# Altium schematic sheet power.SchDoc(power)
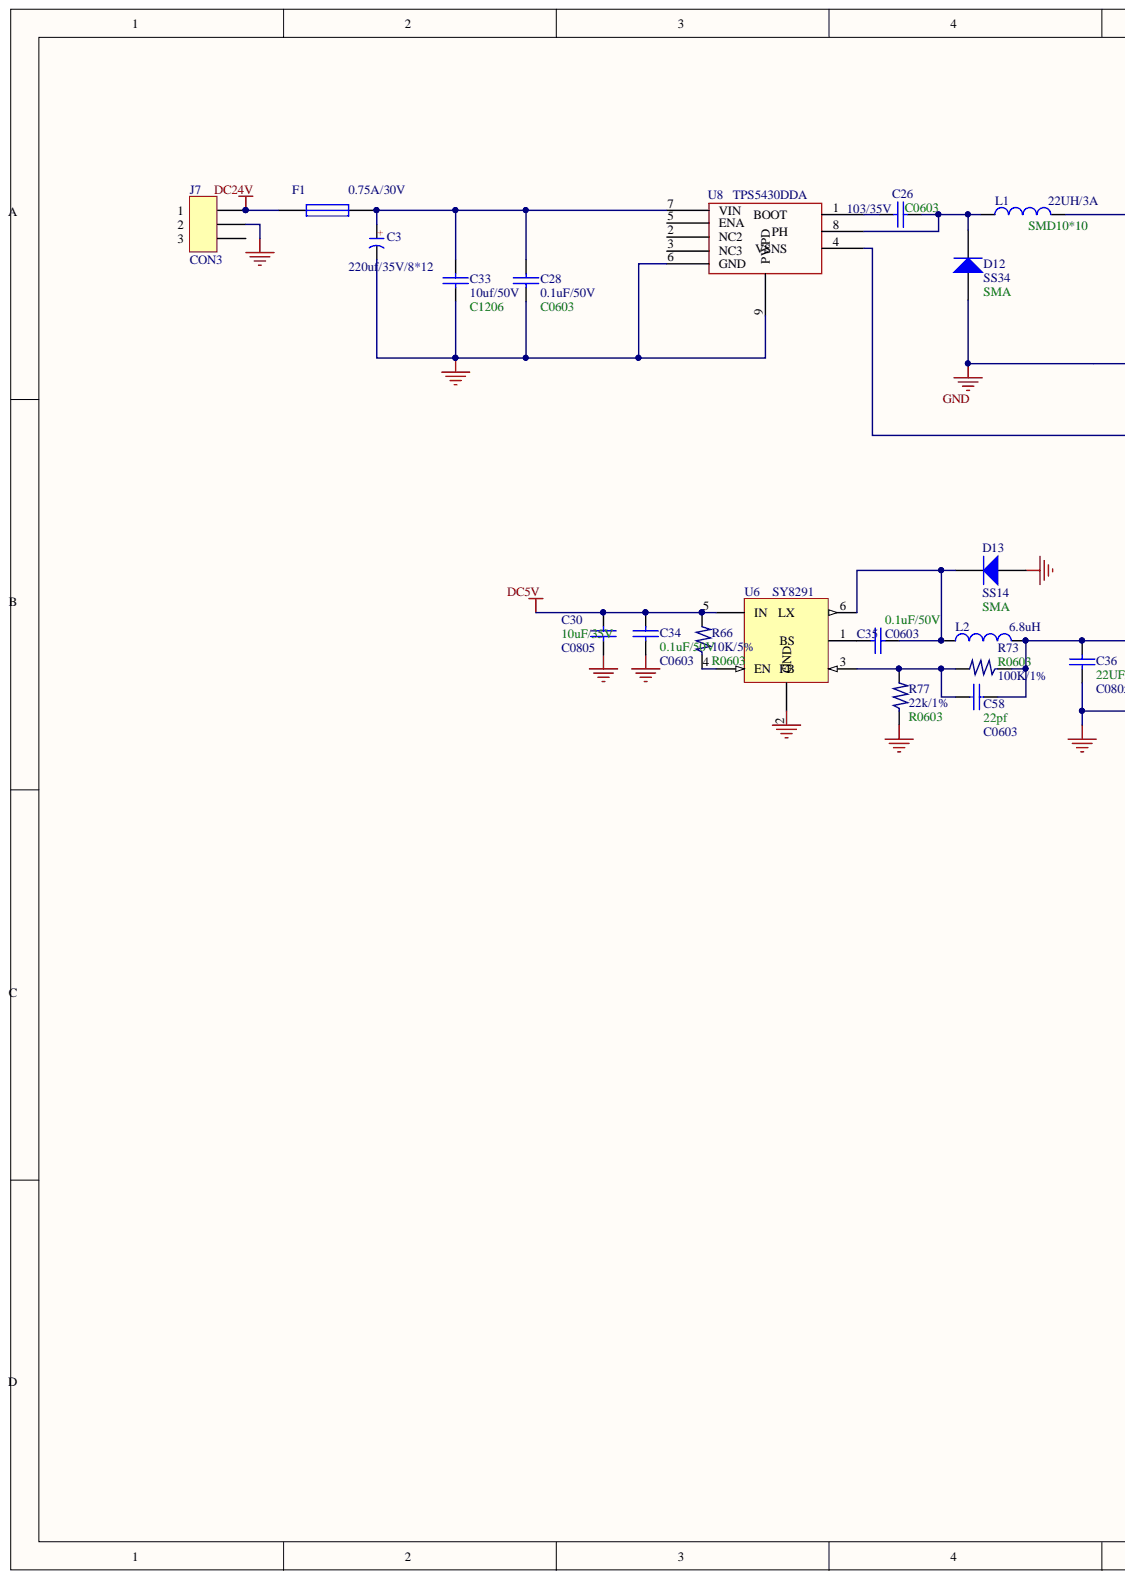
[diagram p0: power.SchDoc, left]
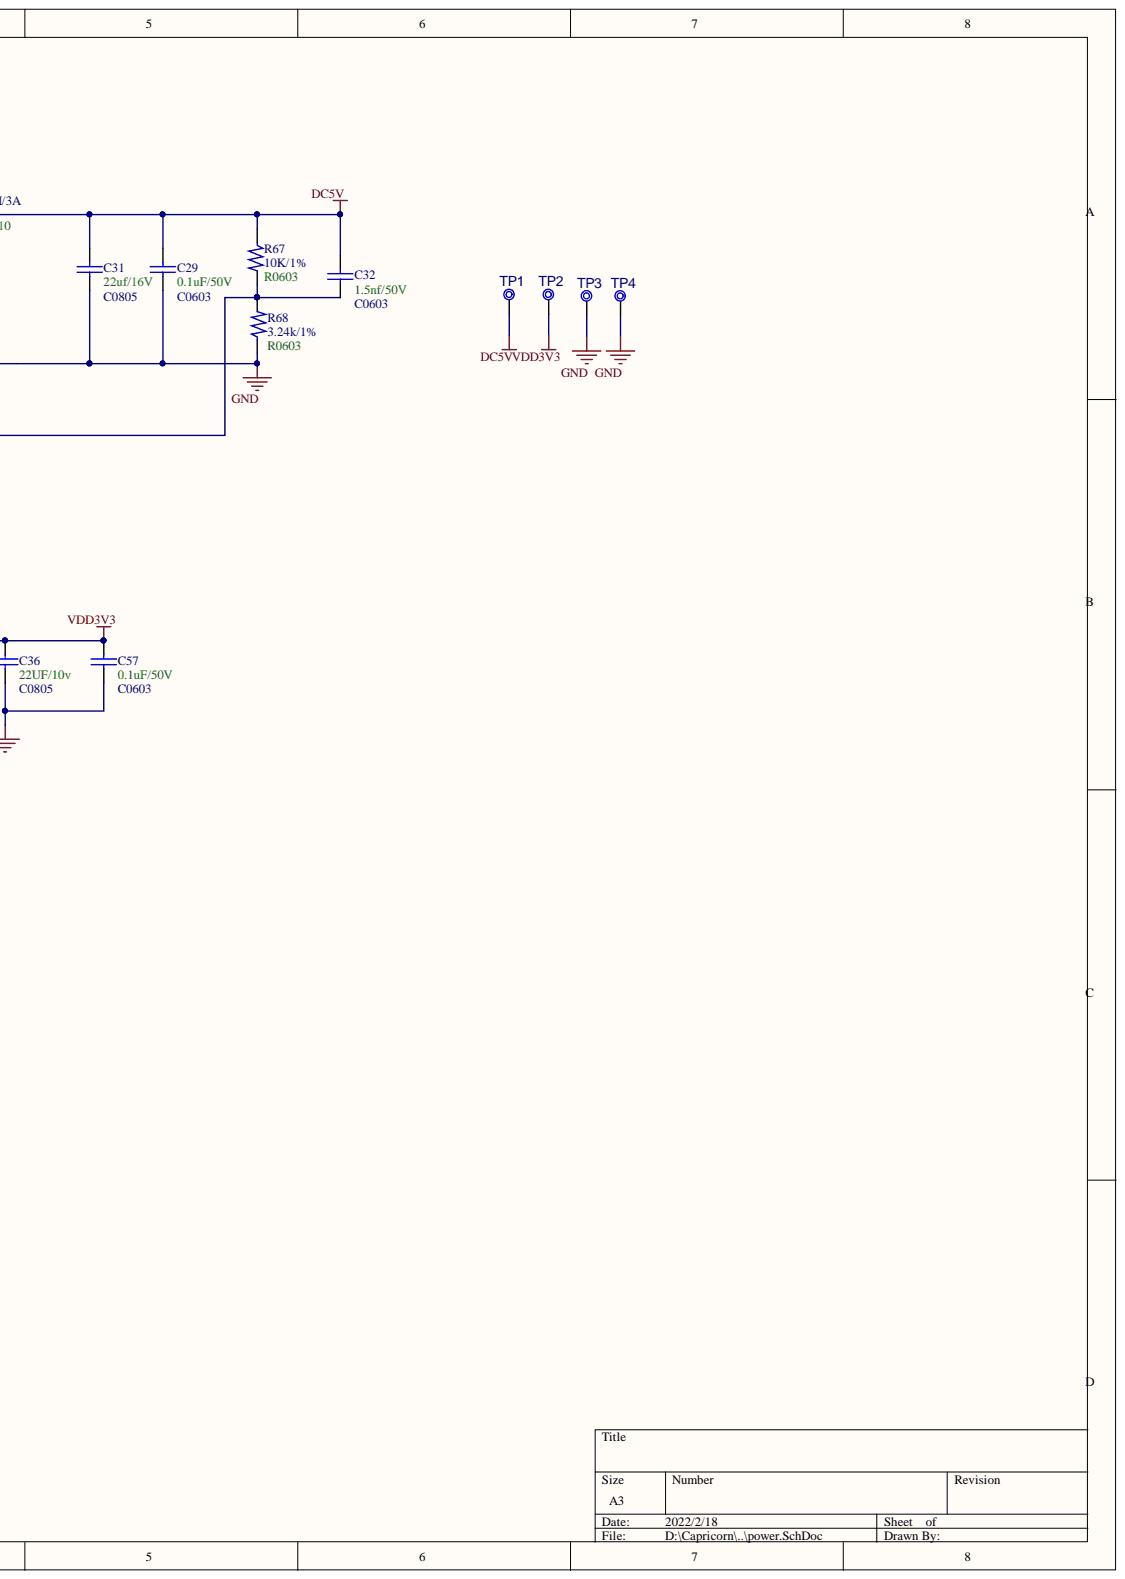
[diagram p1: power.SchDoc, right]

## Parts
C3  220uf/35V/8*12  [CAP8*12]
C26  103/35V  value C0603  [0603_C]
C28  0.1uF/50V  value C0603  [0603_C]
C29  0.1uF/50V  value C0603  [0603_C]
C30  10uF/35V  value C0805  [0805_C]
C31  22uf/16V  value C0805  [0805_C]
C32  1.5nf/50V  value C0603  [0603_C]
C33  10uf/50V  f: C1206  [1206_C]
C34  0.1uF/50V  value C0603  [0603_C]
C35  0.1uF/50V  value C0603  [0603_C]
C36  22UF/10v  value C0805  [0805_C]
C57  0.1uF/50V  value C0603  [0603_C]
C58  22pf  value C0603  [0603_C]
D12  SS34  [SMA(DO-214AC)_S1]
D13  SS14  [SMA(DO-214AC)_S1]
F1  0.75A/30V  [1206_R]
J7  CON3  [POWER]
L1  22UH/3A  value SMD10*10  [IND-SMD_L10.5-W10.3_SLD10D50]
L2  6.8uH  [L-SPD]
R66  10K/5%  [R0603]
R67  10K/1%  [R0603]
R68  3.24k/1%  [R0603]
R73  100K/1%  [R0603]
R77  22k/1%  [R0603]
TP1  Test Point No BOM  [TP_1MM_SMD]  (value not drawn)  (no BOM)
TP2  Test Point No BOM  [TP_1MM_SMD]  (value not drawn)  (no BOM)
TP3  Test Point No BOM  [TP_1MM_SMD]  (value not drawn)  (no BOM)
TP4  Test Point No BOM  [TP_1MM_SMD]  (value not drawn)  (no BOM)
U6  SY8291  [SOT-23-6]
U8  TPS5430DDA  [SOIC-8_L5.0-W4.0-P1.27-LS6.0-BL-EP2.0]

## Nets
DC5V: C29.2 C30.1 C31.1 C32.2 C34.1 L1.1 R66.1 R67.2 TP1.1 U6.5(IN)
DC24V: F1.1 J7.1
GND: C3.2 C28.2 C29.1 C30.2 C31.2 C33.2 C34.2 C36.2 C57.2 D12.1 D13.1 J7.2 R68.2 R77.2 TP3.1 TP4.1 U6.2(FB) U8.6(GND) U8.9(PWPD)
VDD3V3: C36.1 C57.1 C58.2 L2.1 R73.2 TP2.1
NetC3_1: C3.1 C28.1 C33.1 F1.2 U8.7(VIN)
NetC26_1: C26.1 U8.1
NetC26_2: C26.2 D12.2 L1.2 U8.8
NetC32_1: C32.1 R67.1 R68.1 U8.4
NetC35_1: C35.1 U6.1
NetC35_2: C35.2 D13.2 L2.2 U6.6
NetC58_1: C58.1 R73.1 R77.1 U6.3
NetR66_2: R66.2 U6.4(EN)

Not connected: J7 3; U8 2(NC2) 3(NC3) 5(ENA)

## Library data of the parts
C3 = C-CAP: 直插电解电容; 版权归属: 源创客; BOM名称: 直插电解电容; Component Kind: Standard; Library Name: 3.1   - 电阻-电容-电感.SchLib; 学习资源: www.ooocke.com
C26 C28 C29 C31-C33 C35 = CAP: Capacitor; Component Kind: Standard
C30 C34 C57 C58 = CAP: Component Kind: Standard
  C30: Description: 10uf/35V
  C34 C57: Description: 0.1uf/50V
  C58: Description: 22pf
C36 = CAP: 22UF/50v; Component Kind: Standard
D12 D13 = SMA(DO-214AC) SS54: 二极管; : SMA; Component Kind: Standard; ComponentLink1Description: 供应商链接; ComponentLink1URL: http://www.szlcsc.com/product/details_23178.html; Library Name: Miscellaneous Devices LC.IntLib; Package: SMA(DO-214AC); Supplier: LC; SuppliersPartNumber: C22452
F1 = Fuse 1: Fuse; Component Kind: Standard; LatestRevisionDate: 17-Jul-2002; LatestRevisionNote: Re-released for DXP Platform.; Library Name: Miscellaneous Devices.IntLib; PackageDescription: Thru-Hole; 2 Leads; PackageReference: PIN-W2/E2.8; Published: 8-Jun-2000; Simulation: FUSE; Publisher: Altium Limited
J7 = CON3: Connector; Component Kind: Standard
L1 L2 = L: Component Kind: Standard
R66-R68 R73 R77 = 电阻: Component Kind: Standard
TP1-TP4 = CON1759: Test Point; Database Table Name: Connector; Description_: CON TP PAD PCB 1mm SMD; Dev Tools Status: Design; Owner: Cristian Papuc; Populated: YES; Value: TP PAD PCB 1mm; Category: Connector; ComponentLink1Description: SVN Datasheet; ComponentLink2Description: Local Datasheet; ComponentLink3Description: Open Symbol; ComponentLink4Description: Open Footprint; ComponentLink5Description: Open Footprint 2; ComponentLink6Description: Open Footprint 3; ComponentLink7Description: Open Footprint 4; Library Name: MCHP-CDB.DbLib; MCHP-ID: CON1759; Quantity Override: 1; Revision: A; Status: MCL Design; Symbol: Test Point No BOM; Type: Test Point; ComponentLink3URL: C:\ALTIUM_WORK\00001_MCHP-CDB\Library\LibraryRefs\Test Point No BOM.SchLib; ComponentLink4URL: C:\ALTIUM_WORK\00001_MCHP-CDB\Library\Footprints\TP_1mm_SMD.PcbLib
U6 = PT4101: 带OVP 的白光LED 驱动用升压DC/DC 转换器; Component Kind: Standard
U8 = TPS5430DDA: Component Kind: Standard; Library Name: M4.SchLib
